# Altium schematic sheet CPLD.SchDoc(CPLD)
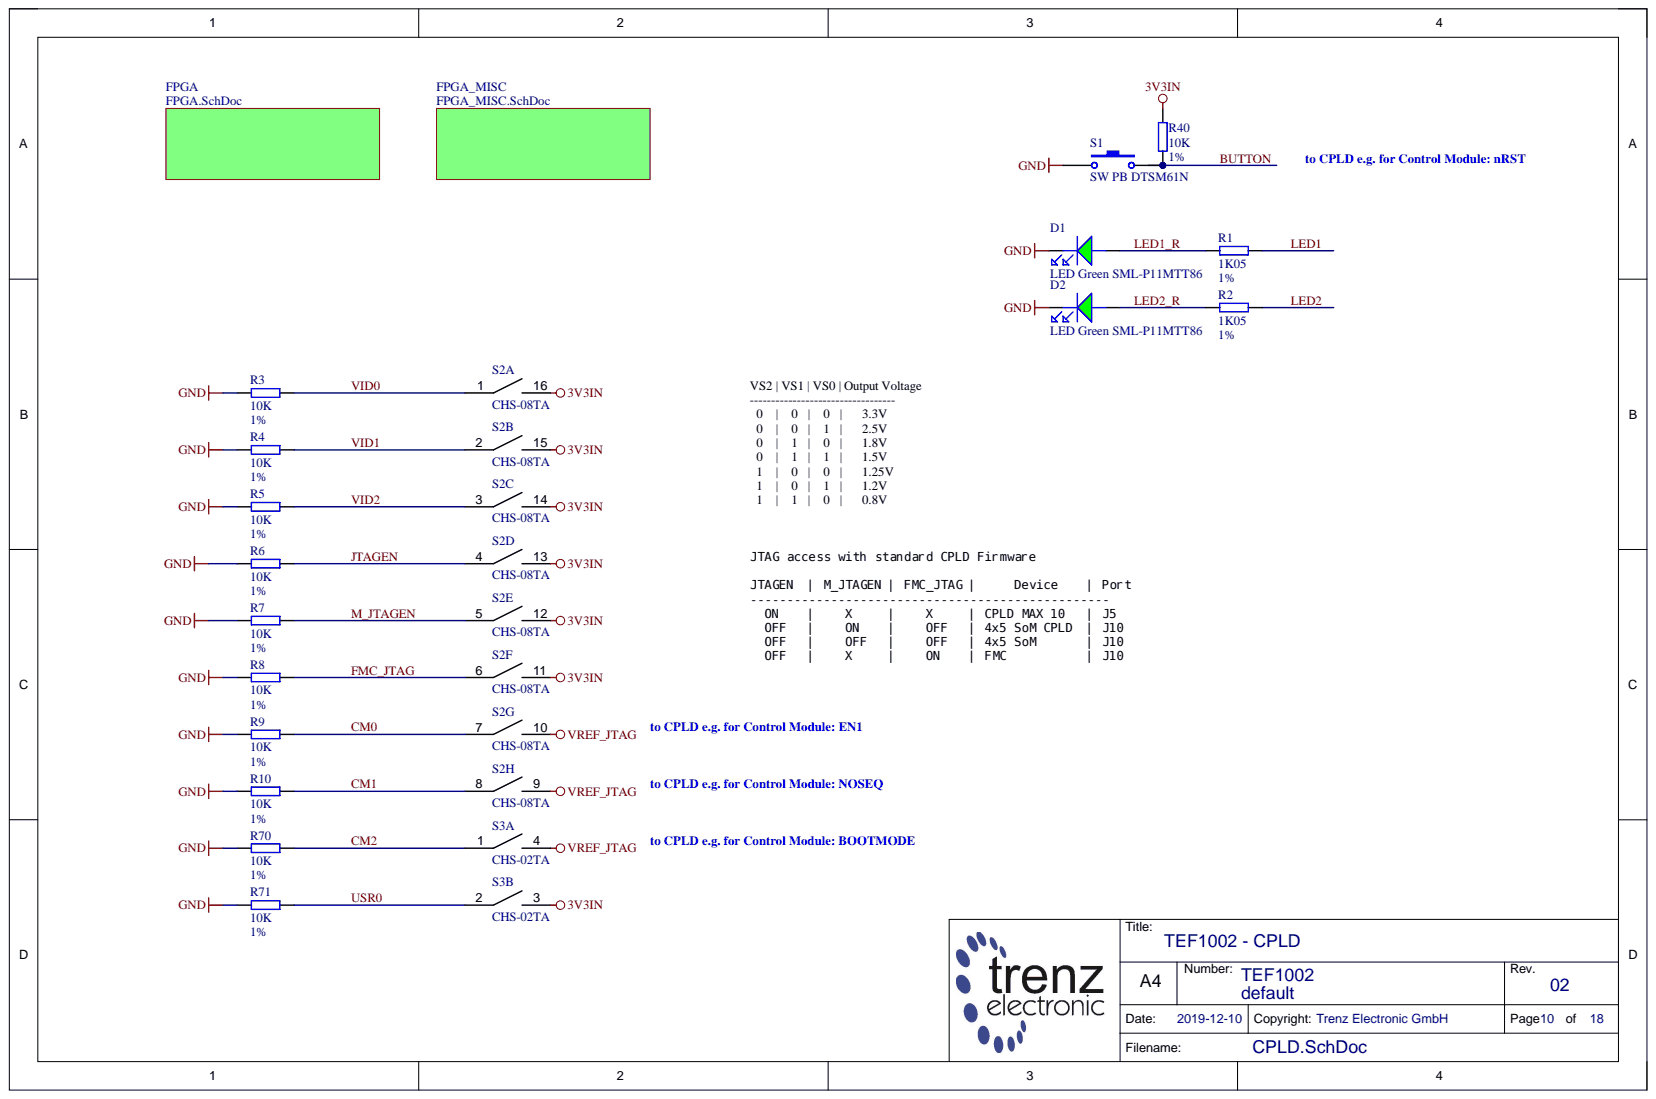
[diagram p0: CPLD.SchDoc]

## Parts
D1  LED Green SML-P11MTT86  Database Table Name: LED  H-ART: SML-P11MTT86  [LEDC1006X25N_GREEN]
D2  LED Green SML-P11MTT86  Database Table Name: LED  H-ART: SML-P11MTT86  [LEDC1006X25N_GREEN]
R1  1K05  [RESC1005X40N]
R2  1K05  Tolerance: 1%  [RESC1005X40N]
R3  10K  [RESC1005X40N]
R4  10K  Tolerance: 1%  [RESC1005X40N]
R5  10K  Tolerance: 1%  [RESC1005X40N]
R6  10K  Tolerance: 1%  [RESC1005X40N]
R7  10K  Tolerance: 1%  [RESC1005X40N]
R8  10K  Tolerance: 1%  [RESC1005X40N]
R9  10K  Tolerance: 1%  [RESC1005X40N]
R10  10K  Tolerance: 1%  [RESC1005X40N]
R40  10K  Tolerance: 1%  [RESC1005X40N]
R70  10K  Tolerance: 1%  [RESC1005X40N]
R71  10K  Tolerance: 1%  [RESC1005X40N]
S1  SW PB DTSM61N  [DTSM61N]
S2  CHS-08TA  [CHS-08TA]  (parts A,B,C,D,E,F,G,H)
S3  CHS-02TA  [CHS-02TA]  (parts A,B)

## Nets
3V3IN: R40.1 S2.11 S2.12 S2.13 S2.14 S2.15 S2.16 S3.3
GND: D1.K D2.K R3.2 R4.2 R5.2 R6.2 R7.2 R8.2 R9.2 R10.2 R70.2 R71.2 S1.1
VREF_JTAG: S2.9 S2.10 S3.4
BUTTON: R40.2 S1.2
CM0: R9.1 S2.7
CM1: R10.1 S2.8
CM2: R70.1 S3.1
FMC_JTAG: R8.1 S2.6
JTAGEN: R6.1 S2.4
LED1: R1.1
LED1_R: D1.A R1.2
LED2: R2.1
LED2_R: D2.A R2.2
M_JTAGEN: R7.1 S2.5
USR0: R71.1 S3.2
VID0: R3.1 S2.1
VID1: R4.1 S2.2
VID2: R5.1 S2.3

## Library data of the parts
D1 D2 = 24211: LED Grün SML-P11MTT86 SMD; Mixed: SMT; Preferred: Yes; Temperature-Range: -40/+85; AN: 24211; Case: 1005 (0402); Component Kind: Standard; Library Name: TE.DbLib; HelpURL: B:\AD\adlib\datasheet\SML-P1
R1 R2 = 25956: WID SMD 1K05 1% 0,063W  BF 1005 (0402); Database Table Name: R_1005_0402; Mixed: SMT; Value: 1.05K; AN: 25956; Case: 1005 (0402); Component Kind: Standard; Library Name: TE.DbLib; Technology: TK100
  R1: Tolerance: 1%
R3-R10 R40 R70 R71 = 22750: WID SMD 10K 1% 0,063W BF 1005 (0402); Database Table Name: R_1005_0402; H-ART: RC0402FR-0710KL; Mixed: SMT; Preferred: Yes; AN: 22750; Case: 1005 (0402); Component Kind: Standard; Library Name: TE.DbLib; Technology: TK100; Value: 10K
  R3: Tolerance: 1%
S1 = 22596: Taster Miniatur Leiterplatte 1.6N; Database Table Name: PB_SW; Mixed: SMT; Preferred: Yes; AN: 22596; Component Kind: Standard; H-ART: DTSM61N; Library Name: TE.DbLib; Trenz-Stock: Yes; HelpURL: B:\AD\adlib\datasheet\DTSM
S2 = 23419: DIP Schalter 8 fach 1,27mm SMD; Database Table Name: PB_SW; Mixed: SMT; Preferred: Yes; AN: 23419; Component Kind: Standard; H-ART: CHS-08TA; Library Name: TE.DbLib; Trenz-Stock: Yes; HelpURL: B:\AD\adlib\datasheet\CHS
S3 = 23416: DIP Schalter 2 fach 1,27mm SMD; Database Table Name: PB_SW; Mixed: SMT; Trenz-Stock: Yes; AN: 23416; Component Kind: Standard; H-ART: CHS-02TA; Library Name: TE.DbLib; HelpURL: B:\AD\adlib\datasheet\CHS
